# Altium schematic sheet Schematic_serial_converter_main.SchDoc
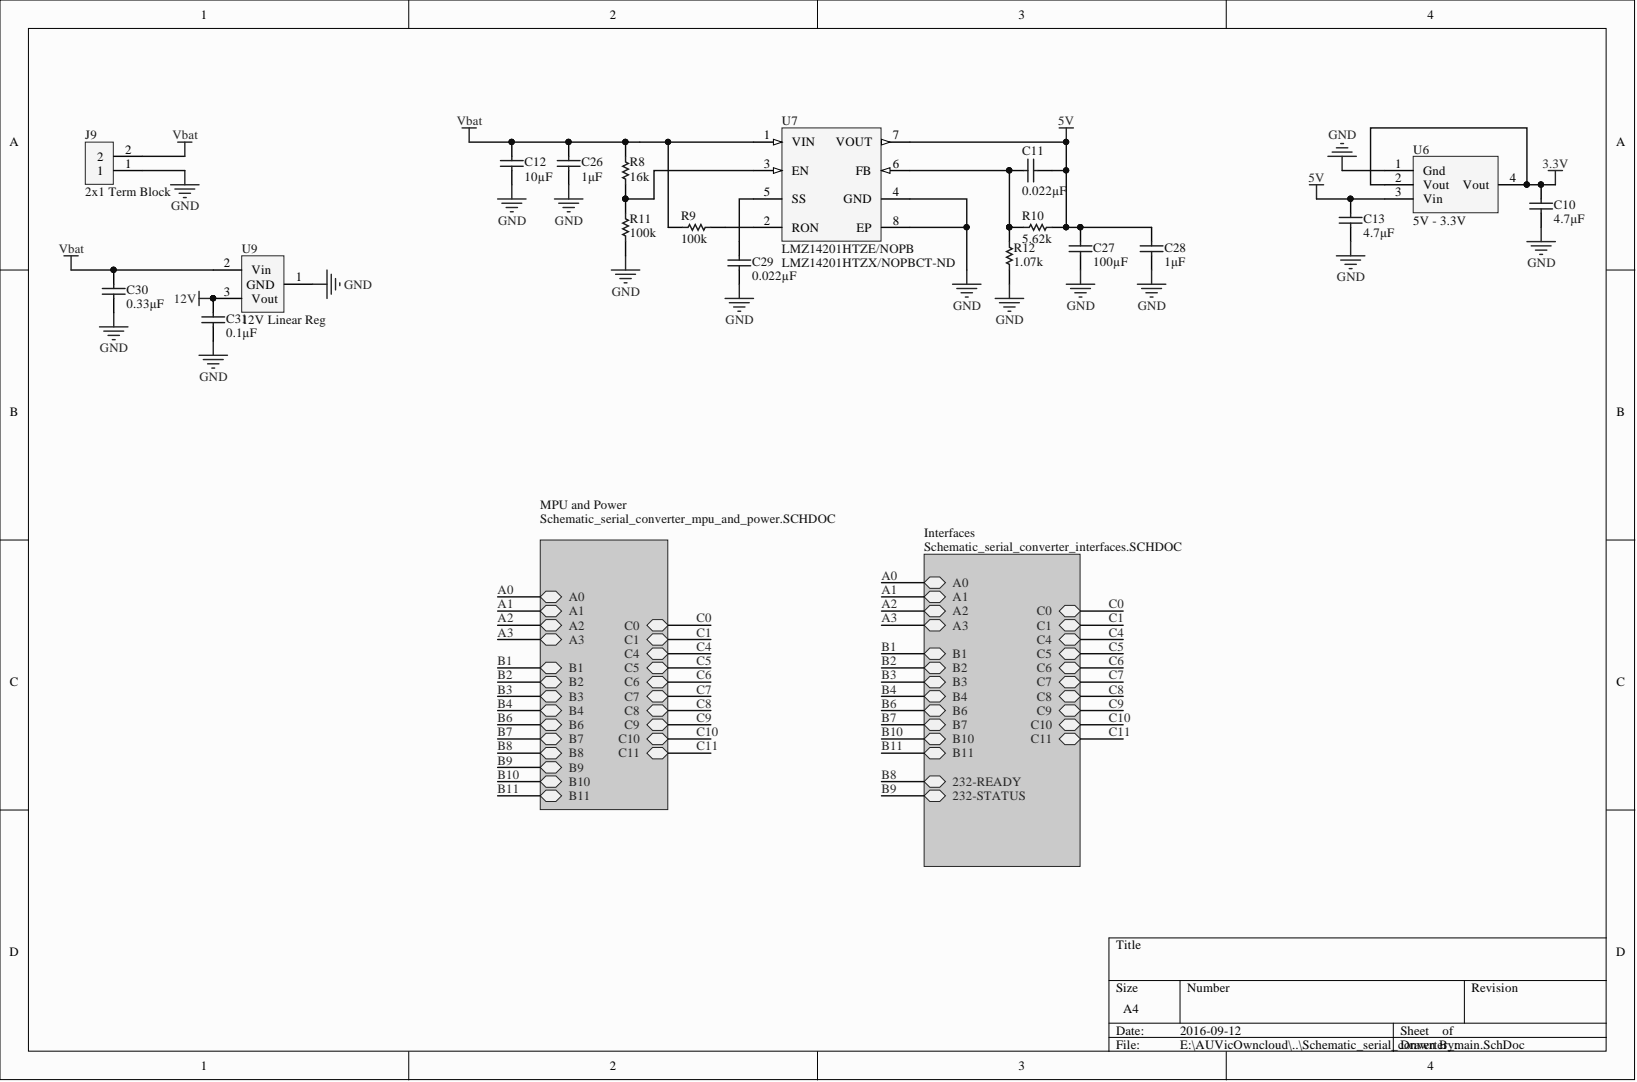
[diagram p0: Schematic_serial_converter_main.SchDoc]

## Parts
C10  4.7μF  [CAPC1608N(0603")]
C11  0.022µF  [CAPC2012N(0805")]
C12  10µF  [CAPC2012N(0805")]
C13  4.7μF  [CAPC1608N(0603")]
C26  1μF  [CAPC1608N(0603")]
C27  100µF  [CAPC3225N(1210")]
C28  1μF  [CAPC1608N(0603")]
C29  0.022µF  [CAPC2012N(0805")]
C30  0.33μF  [CAPC1608N(0603")]
C31  0.1μF  [CAPC1608N(0603")]
J9  2x1 Term Block  [2X1 Terminal Blocks]
R8  16k  [RESC1608N(0603")]
R9  100k  [RESC1608N(0603")]
R10  5.62k  [RESC1608N(0603")]
R11  100k  [RESC1608N(0603")]
R12  1.07k  [RESC1608N(0603")]
U6  5V - 3.3V  [SOT-223-4pin]
U7  LMZ14201HTZE/NOPB  [TZA07A_V]
U9  12V Linear Reg  [T0-252]

## Nets
3.3V: C10.2 U6.2(Vout) U6.4(Vout)
5V: C11.2 C13.2 C27.2 C28.2 R10.2 U6.3(Vin) U7.7(VOUT)
12V: C31.2 U9.3
GND: C10.1 C12.1 C13.1 C26.1 C27.1 C28.1 C29.1 C30.1 C31.1 J9.1 R11.1 R12.1 U6.1(Gnd) U7.4(GND) U7.8(EP) U9.1
VBAT: C12.2 C26.2 C30.2 J9.2 R8.2 R9.2 U7.1(VIN) U9.2
NetC11_1: C11.1 R10.1 R12.2 U7.6(FB)
NetC29_2: C29.2 U7.5(SS)
NetR8_1: R8.1 R11.2 U7.3(EN)
NetR9_1: R9.1 U7.2(RON)
A0:
A1:
A2:
A3:
B1:
B2:
B3:
B4:
B6:
B7:
B8:
B9:
B10:
B11:
C0:
C1:
C4:
C5:
C6:
C7:
C8:
C9:
C10:
C11:

## Library data of the parts
C10 C13 = 4.7uF 10V 0603 SMD, Ceramic: Capacitor, Ceramic, 10V, +/- 10%, 0603 SMD, X5R; MFG: Samsung; MFG#: GRM188R61A475KE15D; Component Kind: Standard; PackageDescription: Chip Capacitor; Published: 16-06-23; Supplier 1: Digi-Key; Supplier Part Number 1: 490-10477-1-ND; Value: 4.7μF; Created By: Ashwin Sira; Library Name: AUVic_Integrated_Library_Capacitors.IntLib; Publisher: AUVic
C11 C29 = 0.022uF 50V 0805 SMD, Ceramic: Capacitor, Ceramic, 50V, +/-5%, 0805 SMD, X7R; Component Kind: Standard; PackageDescription: Chip Capacitor; Published: 14-06-01; Supplier 1: Digi-Key; Supplier Part Number 1: 1276-1826-1-ND; Value: 0.022µF; Library Name: AUVic_Integrated_Library_Capacitors.IntLib; Publisher: AUVic
C12 = 10uF 10V 0805 SMD, Ceramic: Capacitor, Ceramic, 10V, +/- 10%, 0805 SMD, X5R; Made By: Andy Bates; Component Kind: Standard; PackageDescription: Chip Capacitor; Published: 14-06-23; Supplier 1: Digi-Key; Supplier Part Number 1: 490-4735-1-ND; Value: 10µF; Library Name: AUVic_Integrated_Library_Capacitors.IntLib; Publisher: AUVic
C26 C28 = 1uF 25V 0603 SMD, Ceramic: Capacitor, Ceramic, 25V, +/- 10%, 0603 SMD, X5R; MFG: Murata-Electronics-North-America; MFG#: GRM188R61E105KA12D; Component Kind: Standard; PackageDescription: Chip Capacitor; Published: 16-06-27; Supplier 1: Digi-Key; Supplier Part Number 1: 490-3897-1-ND; Value: 1μF; Created By: Andy Bates; Library Name: AUVic_Integrated_Library_Capacitors.IntLib; Publisher: AUVic
C27 = 100uF 6.3V 1210 SMD, Ceramic: Capacitor, Ceramic, 6.3V, +/- 10%, 1210 SMD, X5R; Component Kind: Standard; PackageDescription: Chip Capacitor; Published: 14-06-01; Supplier 1: Digi-Key; Supplier Part Number 1: 478-5047-1-ND; Value: 100µF; Library Name: AUVic_Integrated_Library_Capacitors.IntLib; Publisher: AUVic
C30 = 0.33uF 50V 0603 SMD, Ceramic: Capacitor, Ceramic, 50V, +/- 10%, 0603 SMD, X5R; MFG: TDK; MFG#: C1608X5R1H334K080AB; Component Kind: Standard; PackageDescription: Chip Capacitor; Published: 16-06-29; Supplier 1: Digi-Key; Supplier Part Number 1: 445-7462-1-ND; Value: 0.33μF; Created By: Andy Bates; Library Name: AUVic_Integrated_Library_Capacitors.IntLib; Publisher: AUVic
C31 = 0.1uF 100V 0603 SMD, Ceramic: Capacitor, Ceramic, 100V, +/- 10%, 0603 SMD, X7R; MFG: TDK; MFG#: CGA3E3X7S2A104K080AB; Component Kind: Standard; PackageDescription: Chip Capacitor; Published: 16-04-26; Supplier 1: Digi-Key; Supplier Part Number 1: 445-6938-1-ND; Value: 0.1μF; Created By: Ashwin Sira; Library Name: AUVic_Integrated_Library_Capacitors.IntLib; Publisher: AUVic
J9 = 2x1 Terminal Block: Made by: Andy Bates; Component Kind: Standard; Supplier 1: Digikey; Supplier Part Number 1: ED2740-ND; Library Name: AUVic_Integrated_Library_Connectors.IntLib
R8 = 16kR, 1%, 1/10W, 0603 SMD: Made By: Ashwin Sira; Owned By: AUVic; Component Kind: Standard; Created On: 14-05-31; Description: 16kR, 1%, 1/10W, 0603 SMD; Last Edited: 14-05-31; Library Name: AUVic_Integrated_Library_Resistors.IntLib; Supplier 1: Digi-Key; Supplier Part Number 1: 311-16.0KHRCT-ND; Value: 16k
R9 R11 = 100kR, 1%, 1/10W, 0603 SMD: Made By: Andy Bates; Owned By: AUVic; Component Kind: Standard; Created On: 14-06-30; Description: 100kR, 1%, 1/10W, 0603 SMD; Last Edited: 14-06-30; Library Name: AUVic_Integrated_Library_Resistors.IntLib; Supplier 1: Digi-Key; Supplier Part Number 1: 311-100KGRCT-ND; Value: 100k
R10 = 5.62kR, 1%, 1/10W , 0603, SMD: Component Kind: Standard; Date Created: 2016-06-29; Description: 5.62kR, 1%, 1/10W , 0603, SMD; Supplier 1: Digi-Key; Supplier Part Number 1: 311-5.62KHRCT-ND; Value: 5.62k; Created By: Andy Bates; Library Name: AUVic_Integrated_Library_Resistors.IntLib; Publisher: AUVic
R12 = 1.07kR, 1%, 1/10W , 0603, SMD: Component Kind: Standard; Date Created: 2016-06-25; Description: 1.07kR, 1%, 1/10W , 0603, SMD; Supplier 1: Digi-Key; Supplier Part Number 1: RMCF0603FT1K07CT-ND; Value: 1.07k; Created By: Ashwin Sira; Library Name: AUVic_Integrated_Library_Resistors.IntLib; Publisher: AUVic
U6 = 5V-3.3V voltage reguglator: 5V to 3.3 V linear regulator; Made By: Andy Bates; Component Kind: Standard; Supplier 1: Digikey; Supplier 1 Part Number: AP2114H-3.3TRG1DICT-ND; Library Name: AUVic_Integrated_Library_Regulators.IntLib
U7 = LMZ14201HTZE/NOPB: 1A SIMPLE SWITCHER® Power Module with 42V Maximum Input, 7-pin TO-PMOD, Pb-Free, 45 Rail; Manufacturer: National Semiconductor; High-Reliability: Y; PackageTopMarking: NSUZXYTTE#*LMZ14201*HTZ; Component Kind: Standard; ComponentLink1Description: Manufacturer URL; ComponentLink1URL: http://www.national.com/; ComponentLink2Description: LMZ14201 Datasheet; ComponentLink2URL: http://www.national.com/ds/LM/LMZ14201H.pdf; ComponentLink3Description: LMZ14201H Web Page; ComponentLink3URL: http://www.national.com/pf/LM/LMZ14201H.html; ComponentLink4Description: TZA07A Package Page; ComponentLink4URL: http://www.national.com/packaging/folders/tza07a.html; CompType: Switcher; DatasheetVersion: Jan-2011; PackageDescription: TO-PMOD-7, 10.16 x 9.85 x 4.57 mm Body, 7 Lead, 1.27mm Pitch, Surface Mount; PackageReference: TZA07A; PackageVersion: revD, Apr-2011; PartNumber: LMZ14201HTZE/NOPB; PinCount: 7; PowerWise: Y; RoHS: Y; Supplier 1: Digi-Key; Supplier Part Number 1: LMZ14201HTZX/NOPBCT-ND; Library Name: AUVic_Integrated_Library_Regulators.IntLib
U9 = 12V Linear Regulator: Made By: Andy Bates; Component Kind: Standard; Supplier 1: Digikey; Supplier 1 Part Number: 497-1209-1-ND; Library Name: AUVic_Integrated_Library_Regulators.IntLib
